# Altium schematic sheet J_TAG_SCH.SchDoc
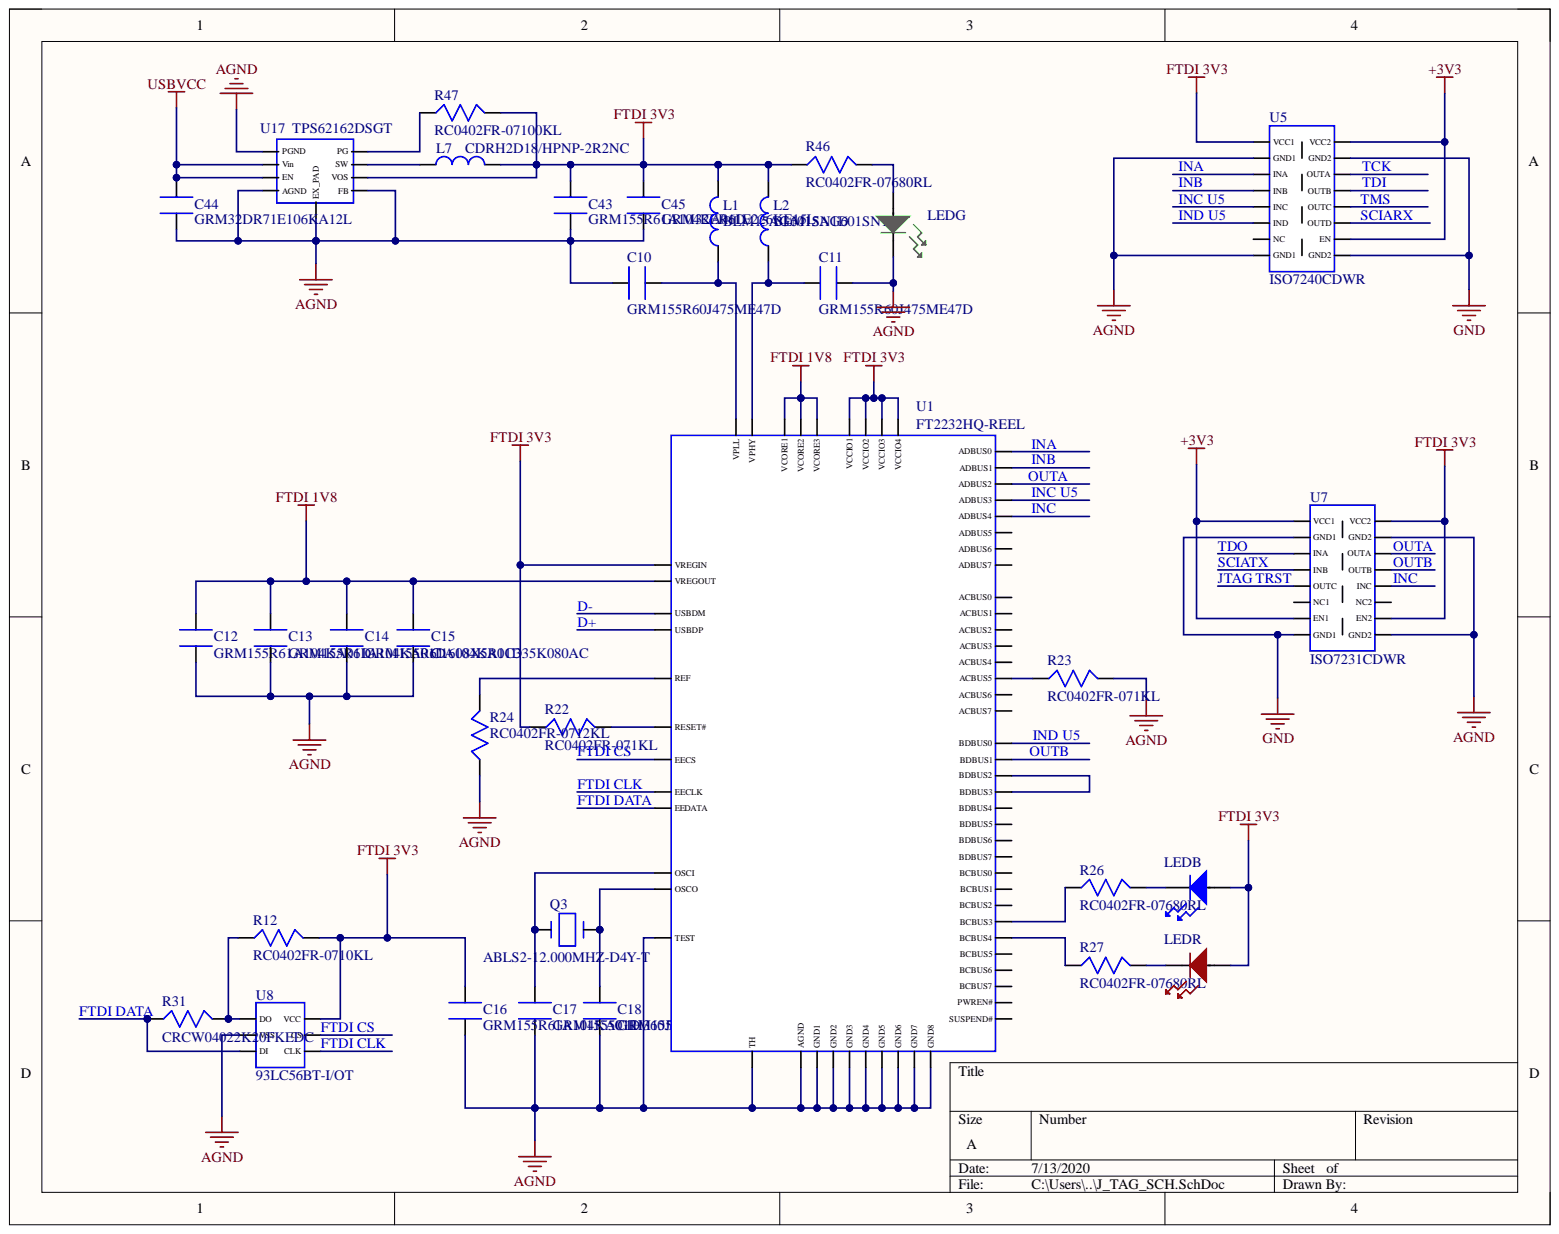
[diagram p0: J_TAG_SCH.SchDoc]

## Parts
C10  GRM155R60J475ME47D  [GRM155R60J475ME47D]
C11  GRM155R60J475ME47D  [GRM155R60J475ME47D]
C12  GRM155R61A104KA01D  [GRM155R61A104KA01D]
C13  GRM155R61A104KA01D  [GRM155R61A104KA01D]
C14  GRM155R61A104KA01D  [GRM155R61A104KA01D]
C15  C1608X5R1C335K080AC  [C1608X5R1C335K080AC]
C16  GRM155R61A104KA01D  [GRM155R61A104KA01D]
C17  GRM1555C1H360JA01D  [GRM1555C1H360JA01D]
C18  GRM1555C1H360JA01D  [GRM1555C1H360JA01D]
C43  GRM155R61A104KA01D  [GRM155R61A104KA01D]
C44  GRM32DR71E106KA12L  [GRM32DR71E106KA12L]
C45  GRM32ER61E226KE15L  [GRM32ER61E226KE15L]
L1  BLM15AG601SN1D  [BLM15AG601SN1D]
L2  BLM15AG601SN1D  [BLM15AG601SN1D]
L7  CDRH2D18/HPNP-2R2NC  [CDRH2D18/HPNP-2R2NC]
LEDB  150080BS75000  [150080BS75000]  (value not drawn)
LEDG  150080VS75000  [150080VS75000]  (value not drawn)
LEDR  150080SS75000  [150080SS75000]  (value not drawn)
Q3  ABLS2-12.000MHZ-D4Y-T  [ABLS2-12.000MHZ-D4Y-T]
R12  RC0402FR-0710KL  [RC0402FR-0710KL]
R22  RC0402FR-071KL  [RC0402FR-071KL]
R23  RC0402FR-071KL  [RC0402FR-071KL]
R24  RC0402FR-0712KL  [RC0402FR-0712KL]
R26  RC0402FR-07680RL  [RC0402FR-07680RL]
R27  RC0402FR-07680RL  [RC0402FR-07680RL]
R31  CRCW04022K20FKEDC  [CRCW04022K20FKEDC]
R46  RC0402FR-07680RL  [RC0402FR-07680RL]
R47  RC0402FR-07100KL  [RC0402FR-07100KL]
U1  FT2232HQ-REEL  [FT2232HQ-REEL]
U5  ISO7240CDWR  [ISO7240CDWR]
U7  ISO7231CDWR  [ISO7231CDWR]
U8  93LC56BT-I/OT  [93LC56BT-I/OT]
U17  TPS62162DSGT  [TPS62162DSGT]

## Nets
+3V3: U5.EN U5.VCC2 U7.EN1 U7.VCC1
AGND: C10.1 C11.2 C12.1 C13.1 C14.1 C15.1 C16.1 C17.1 C18.1 C43.1 C44.1 C45.1 LEDG.K R23.2 R24.1 U1.AGND U1.GND1 U1.GND2 U1.GND3 U1.GND4 U1.GND5 U1.GND6 U1.GND7 U1.GND8 U1.TEST U1.TH U5.GND1 U7.GND2 U8.VSS U17.AGND U17.EX_PAD U17.FB U17.PGND
FTDI 1V8: C12.2 C13.2 C14.2 C15.2 U1.VCORE1 U1.VCORE2 U1.VCORE3 U1.VREGOUT
FTDI 3V3: C16.2 C43.2 C45.2 L1.1 L2.1 L7.1 LEDB.A LEDR.A R12.2 R22.1 R46.1 R47.2 U1.VCCIO1 U1.VCCIO2 U1.VCCIO3 U1.VCCIO4 U1.VREGIN U5.VCC1 U7.EN2 U7.VCC2 U8.VCC U17.VOS
GND: U5.GND2 U7.GND1
USBVCC: C44.2 U17.EN U17.Vin
D+: U1.USBDP
D-: U1.USBDM
FTDI CLK: U1.EECLK U8.CLK
FTDI CS: U1.EECS U8.CS
FTDI DATA: R31.1 U1.EEDATA U8.DI
INA: U1.ADBUS0 U5.INA
INB: U1.ADBUS1 U5.INB
INC: U1.ADBUS4 U7.INC
INC U5: U1.ADBUS3 U5.INC
IND U5: U1.BDBUS0 U5.IND
JTAG TRST: U7.OUTC
OUTA: U1.ADBUS2 U7.OUTA
OUTB: U1.BDBUS1 U7.OUTB
SCIARX: U5.OUTD
SCIATX: U7.INB
TCK: U5.OUTA
TDI: U5.OUTB
TDO: U7.INA
TMS: U5.OUTC
NetC10_2: C10.2 L1.2 U1.VPLL
NetC11_1: C11.1 L2.2 U1.VPHY
NetC17_2: C17.2 Q3.1 U1.OSCI
NetC18_2: C18.2 Q3.2 U1.OSCO
NetL7_2: L7.2 U17.SW
NetLEDB_K: LEDB.K R26.2
NetLEDG_A: LEDG.A R46.2
NetLEDR_K: LEDR.K R27.2
NetR12_1: R12.1 R31.2 U8.DO
NetR22_2: R22.2 U1.RESET#
NetR23_1: R23.1 U1.ACBUS5
NetR24_2: R24.2 U1.REF
NetR26_1: R26.1 U1.BCBUS3
NetR27_1: R27.1 U1.BCBUS4
NetR47_1: R47.1 U17.PG
NetU1_BDBUS2: U1.BDBUS2 U1.BDBUS3

Not connected: U1 ACBUS0 ACBUS1 ACBUS2 ACBUS3 ACBUS4 ACBUS6 ACBUS7 ADBUS5 ADBUS6 ADBUS7 BCBUS0 BCBUS1 BCBUS2 BCBUS5 BCBUS6 BCBUS7 BDBUS4 BDBUS5 BDBUS6 BDBUS7 PWREN# SUSPEND#; U5 NC; U7 NC1 NC2

## Library data of the parts
C10 C11 = GRM155R60J475ME47D: C10, C11; Component Kind: Standard; Supplier 1: Digi-Key; Supplier Part Number 1: 490-5915-1-ND; Library Name: J_Tag.SchLib
C12-C14 C16 C43 = GRM155R61A104KA01D: C12, C13, C14, C16, C43; Component Kind: Standard; Supplier 1: Digi-Key; Supplier Part Number 1: 490-1318-6-ND; Library Name: J_Tag.SchLib
C15 = C1608X5R1C335K080AC: C15; Component Kind: Standard; Supplier 1: Digi-Key; Supplier Part Number 1: 445-7476-6-ND; Library Name: J_Tag.SchLib
C17 C18 = GRM1555C1H360JA01D: C17, C18; Component Kind: Standard; Supplier 1: Digi-Key; Supplier Part Number 1: 490-6044-6-ND; Library Name: J_Tag.SchLib
C44 = GRM32DR71E106KA12L: C41, C44, 10u; Component Kind: Standard; Supplier 1: Digi-Key; Supplier Part Number 1: 490-1867-6-ND; Library Name: J_Tag.SchLib
C45 = GRM32ER61E226KE15L: C45; Component Kind: Standard; Supplier 1: Digi-Key; Supplier Part Number 1: 490-3889-6-ND; Library Name: J_Tag.SchLib
L1 L2 = BLM15AG601SN1D: L1, L2; Component Kind: Standard; Supplier 1: Digi-Key; Supplier Part Number 1: 490-1006-6-ND; Library Name: J_Tag.SchLib
L7 = CDRH2D18/HPNP-2R2NC: L7; Component Kind: Standard; Supplier 1: Digi-Key; Supplier Part Number 1: 308-2295-6-ND; Library Name: J_Tag.SchLib
LEDB = 150080BS75000onent_1: BLUE LED; Component Kind: Standard; Supplier 1: Digi-Key; Supplier Part Number 1: 732-4982-6-ND; Library Name: J_Tag.SchLib
LEDG = 150080VS75000: GREEN LED; Component Kind: Standard; Supplier 1: Digi-Key; Supplier Part Number 1: 732-4986-6-ND; Library Name: J_Tag.SchLib
LEDR = 150080SS75000: RED LED; Component Kind: Standard; Supplier 1: Digi-Key; Supplier Part Number 1: 732-4985-6-ND; Library Name: J_Tag.SchLib
Q3 = ABLS2-12.000MHZ-D4Y-T: Oscilator 12MHz; Component Kind: Standard; Supplier 1: Digi-Key; Supplier Part Number 1: 535-9869-6-ND; Library Name: J_Tag.SchLib
R12 = RC0402FR-0710KL: R12, 10K; Component Kind: Standard; Supplier 1: Digi-Key; Supplier Part Number 1: 311-10.0KLRDKR-ND; Library Name: J_Tag.SchLib
R22 R23 = RC0402FR-071KL: R22, R23, 1K; Component Kind: Standard; Supplier 1: Digi-Key; Supplier Part Number 1: 311-1.00KLRDKR-ND; Library Name: J_Tag.SchLib
R26 R27 R46 = RC0402FR-07680RL: R26, R27, R46, RC0402FR-07680RL; Component Kind: Standard; Supplier 1: Digi-Key; Supplier Part Number 1: 311-680LRDKR-ND; Library Name: J_Tag.SchLib
R31 = CRCW04022K20FKEDC: R31; Component Kind: Standard; Supplier 1: Digi-Key; Supplier Part Number 1: 541-3971-6-ND; Library Name: J_Tag.SchLib
R47 = RC0402FR-07100KL: R47, 100K; Component Kind: Standard; Supplier 1: Digi-Key; Supplier Part Number 1: 311-100KLRDKR-ND; Library Name: J_Tag.SchLib
U1 = FT2232HQ-REEL: IC kontroler; Component Kind: Standard; Supplier 1: Digi-Key; Supplier Part Number 1: 768-1025-6-ND; Library Name: J_Tag.SchLib
U5 = ISO7240CDWR: Izolator; Component Kind: Standard; Supplier 1: Digi-Key; Supplier Part Number 1: 296-38555-6-ND; Library Name: J_Tag.SchLib
U7 = ISO7231CDWR: Izolator; Component Kind: Standard; Supplier 1: Digi-Key; Supplier Part Number 1: 296-38966-6-ND; Library Name: J_Tag.SchLib
U8 = 93LC56BT-I/OT: IC memorija; Component Kind: Standard; Supplier 1: Digi-Key; Supplier Part Number 1: 93LC56BT-I/OTDKR-ND; Library Name: J_Tag.SchLib
U17 = TPS62162DSGT: DC-DC Buck; Component Kind: Standard; Supplier 1: Digi-Key; Supplier Part Number 1: 296-29897-1-ND; Library Name: J_Tag.SchLib
R24 = RC0402FR-0712KL: Component Kind: Standard; Supplier 1: Digi-Key; Supplier Part Number 1: 311-12.0KLRDKR-ND; Library Name: J_Tag.SchLib
